# Altium schematic sheet JGL1904_FireAnt_Interface_V1.3.SchDoc(JGL1904_FireAnt_Interface_V1.3)
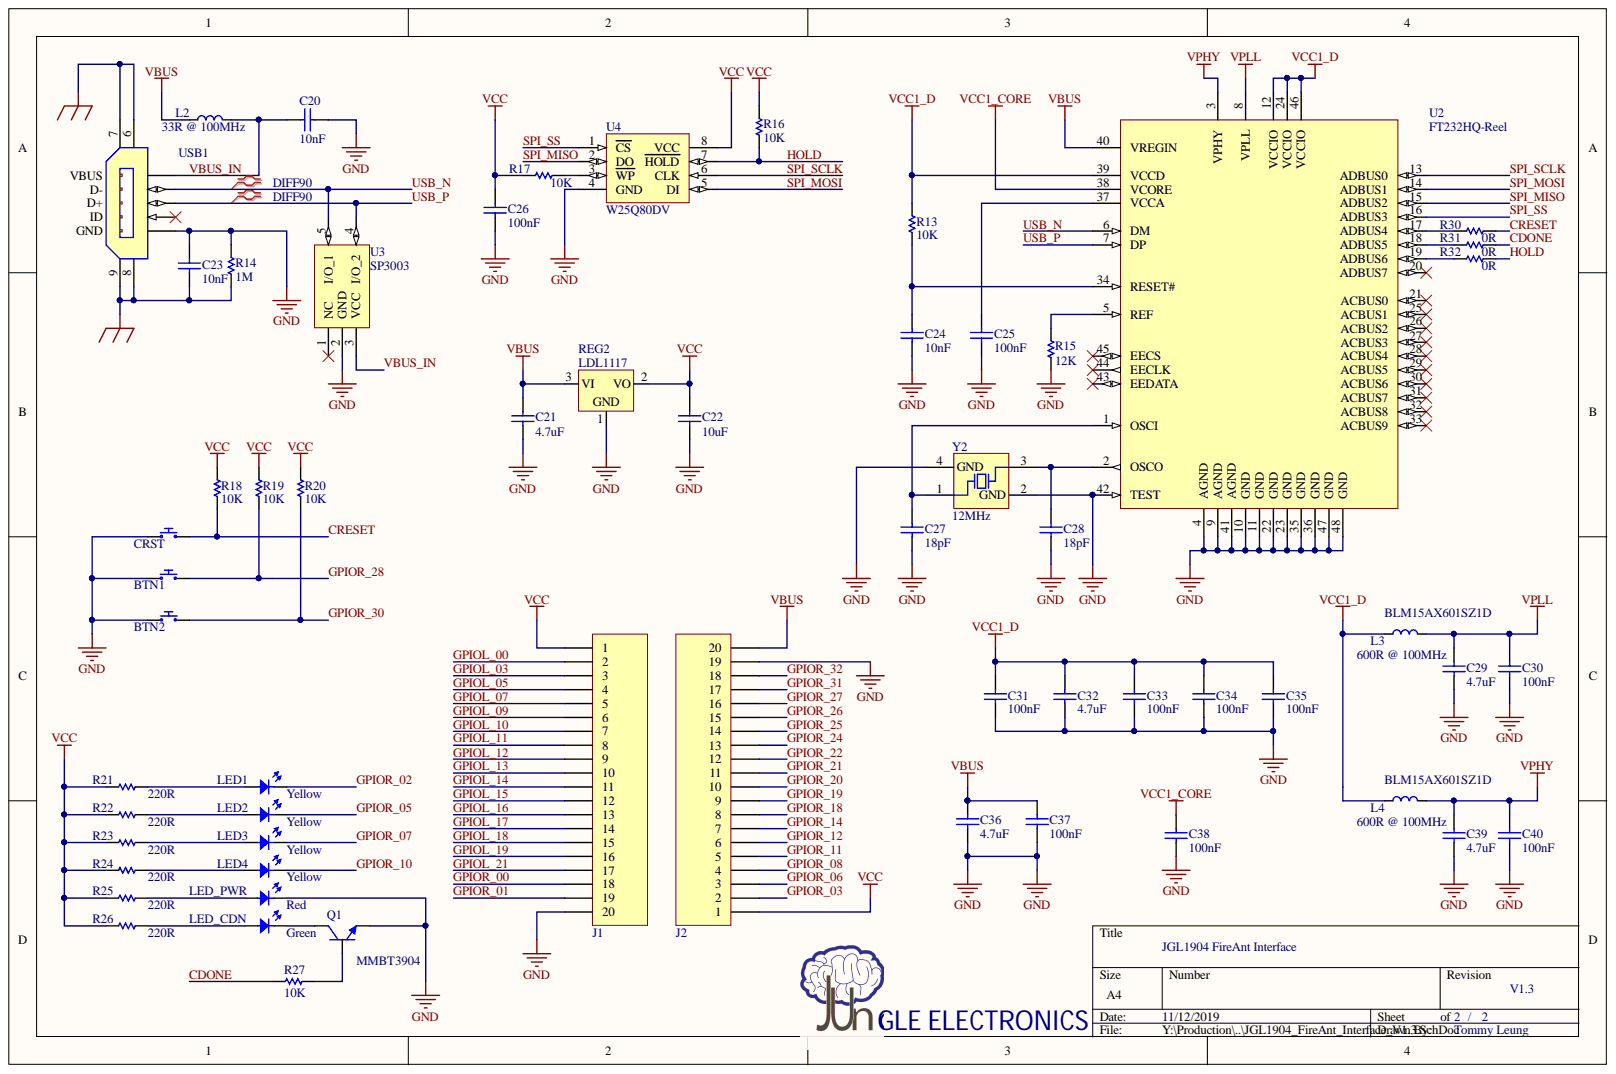
[diagram p0: JGL1904_FireAnt_Interface_V1.3.SchDoc]

## Parts
BTN1  SWITCH_SPST_PUSHON  [PUSHBUTTON-3x4x2]  (value not drawn)
BTN2  SWITCH_SPST_PUSHON  [PUSHBUTTON-3x4x2]  (value not drawn)
C20  value 10nF  [CAPC0402M]  (hidden comment: CAPACITOR)
C21  value 4.7uF  [CAPC0402M]  (hidden comment: CAPACITOR)
C22  value 10uF  [CAPC0402M]  (hidden comment: CAPACITOR)
C23  value 10nF  [CAPC0402M]  (hidden comment: CAPACITOR)
C24  value 10nF  [CAPC0402M]  (hidden comment: CAPACITOR)
C25  value 100nF  [CAPC0402M]  (hidden comment: CAPACITOR)
C26  value 100nF  [CAPC0402M]  (hidden comment: CAPACITOR)
C27  value 18pF  [CAPC0402M]  (hidden comment: CAPACITOR)
C28  value 18pF  [CAPC0402M]  (hidden comment: CAPACITOR)
C29  value 4.7uF  [CAPC0402M]  (hidden comment: CAPACITOR)
C30  value 100nF  [CAPC0402M]  (hidden comment: CAPACITOR)
C31  value 100nF  [CAPC0402M]  (hidden comment: CAPACITOR)
C32  value 4.7uF  [CAPC0402M]  (hidden comment: CAPACITOR)
C33  value 100nF  [CAPC0402M]  (hidden comment: CAPACITOR)
C34  value 100nF  [CAPC0402M]  (hidden comment: CAPACITOR)
C35  value 100nF  [CAPC0402M]  (hidden comment: CAPACITOR)
C36  value 4.7uF  [CAPC0402M]  (hidden comment: CAPACITOR)
C37  value 100nF  [CAPC0402M]  (hidden comment: CAPACITOR)
C38  value 100nF  [CAPC0402M]  (hidden comment: CAPACITOR)
C39  value 4.7uF  [CAPC0402M]  (hidden comment: CAPACITOR)
C40  value 100nF  [CAPC0402M]  (hidden comment: CAPACITOR)
CRST  SWITCH_SPST_PUSHON  [PUSHBUTTON-3x4x2]  (value not drawn)
J1  Header  [PH-1R20P-2M54]  (value not drawn)
J2  Header  [PH-1R20P-2M54]  (value not drawn)
L2  value 33R @ 100MHz  [RESC0603M]  (hidden comment: FERRITE_BEADS)
L3  value 600R @ 100MHz  Mfr. PN: BLM15AX601SZ1D  [RESC0402M]  (hidden comment: FERRITE_BEADS)
L4  value 600R @ 100MHz  Mfr. PN: BLM15AX601SZ1D  [RESC0402M]  (hidden comment: FERRITE_BEADS)
LED1  LED  value Yellow  [LED0603]
LED2  LED  value Yellow  [LED0603]
LED3  LED  value Yellow  [LED0603]
LED4  LED  value Yellow  [LED0603]
LED_CDN  LED  value Green  [LED0603]
LED_PWR  LED  value Red  [LED0603]
Q1  MMBT3904  [SOT-23]
R13  value 10K  [RESC0402M]  (hidden comment: RESISTOR)
R14  value 1M  [RESC0402M]  (hidden comment: RESISTOR)
R15  value 12K  [RESC0402M]  (hidden comment: RESISTOR)
R16  value 10K  [RESC0402M]  (hidden comment: RESISTOR)
R17  value 10K  [RESC0402M]  (hidden comment: RESISTOR)
R18  value 10K  [RESC0402M]  (hidden comment: RESISTOR)
R19  value 10K  [RESC0402M]  (hidden comment: RESISTOR)
R20  value 10K  [RESC0402M]  (hidden comment: RESISTOR)
R21  value 220R  [RESC0402M]  (hidden comment: RESISTOR)
R22  value 220R  [RESC0402M]  (hidden comment: RESISTOR)
R23  value 220R  [RESC0402M]  (hidden comment: RESISTOR)
R24  value 220R  [RESC0402M]  (hidden comment: RESISTOR)
R25  value 220R  [RESC0402M]  (hidden comment: RESISTOR)
R26  value 220R  [RESC0402M]  (hidden comment: RESISTOR)
R27  value 10K  [RESC0402M]  (hidden comment: RESISTOR)
R30  value 0R  [RESC0402M]  (hidden comment: RESISTOR)
R31  value 0R  [RESC0402M]  (hidden comment: RESISTOR)
R32  value 0R  [RESC0402M]  (hidden comment: RESISTOR)
REG2  LDL1117  [SOT-223]
U2  FT232HQ-Reel  [QFN48_N]
U3  SP3003  [SOT-553]
U4  W25Q80DV  [SOIC-8]
USB1  105017-0001  [105017-0001]  (value not drawn)
Y2  value 12MHz  [XTAL-3225]  (hidden comment: XTAL_S3225A)

## Nets
GND: BTN1.1 BTN2.1 C20.2 C21.2 C22.2 C23.1 C24.1 C25.2 C26.1 C27.2 C28.2 C29.1 C30.1 C31.2 C32.1 C33.2 C34.2 C35.2 C36.2 C37.2 C38.2 C39.1 C40.1 CRST.1 J1.20 J2.19 LED_PWR.2 Q1.2 R14.2 R15.1 REG2.1 U2.4(AGND) U2.9(AGND) U2.10(GND) U2.11(GND) U2.22(GND) U2.23(GND) U2.35(GND) U2.36(GND) U2.41(AGND) U2.42(TEST) U2.47(GND) U2.48(GND) U3.2(GND) U4.4(GND) USB1.5 Y2.2(GND) Y2.4(GND)
Shield_USB: C23.2 R14.1 USB1.6 USB1.7 USB1.8 USB1.9
VBUS: C21.1 C36.1 C37.1 J2.20 L2.1 REG2.3 U2.40(VREGIN)
VCC: C22.1 C26.2 J1.1 J2.1 R16.1 R17.1 R18.1 R19.1 R20.1 R21.2 R22.2 R23.2 R24.2 R25.2 R26.2 REG2.2 U4.8(VCC)
VCC1_CORE: C38.1 U2.38(VCORE)
VCC1_D: C31.1 C32.2 C33.1 C34.1 C35.1 L3.1 L4.1 R13.2 U2.12(VCCIO) U2.24(VCCIO) U2.39(VCCD) U2.46(VCCIO)
VPHY: C39.2 C40.2 L4.2 U2.3(VPHY)
VPLL: C29.2 C30.2 L3.2 U2.8(VPLL)
CDONE: R27.2 R31.1
CRESET: CRST.2 R18.2 R30.1
GPIOL_00: J1.2
GPIOL_03: J1.3
GPIOL_05: J1.4
GPIOL_07: J1.5
GPIOL_09: J1.6
GPIOL_10: J1.7
GPIOL_11: J1.8
GPIOL_12: J1.9
GPIOL_13: J1.10
GPIOL_14: J1.11
GPIOL_15: J1.12
GPIOL_16: J1.13
GPIOL_17: J1.14
GPIOL_18: J1.15
GPIOL_19: J1.16
GPIOL_21: J1.17
GPIOR_00: J1.18
GPIOR_01: J1.19
GPIOR_02: LED1.2
GPIOR_03: J2.2
GPIOR_05: LED2.2
GPIOR_06: J2.3
GPIOR_07: LED3.2
GPIOR_08: J2.4
GPIOR_10: LED4.2
GPIOR_11: J2.5
GPIOR_12: J2.6
GPIOR_14: J2.7
GPIOR_18: J2.8
GPIOR_19: J2.9
GPIOR_20: J2.10
GPIOR_21: J2.11
GPIOR_22: J2.12
GPIOR_24: J2.13
GPIOR_25: J2.14
GPIOR_26: J2.15
GPIOR_27: J2.16
GPIOR_28: BTN1.2 R19.2
GPIOR_30: BTN2.2 R20.2
GPIOR_31: J2.17
GPIOR_32: J2.18
HOLD: R16.2 R32.1 U4.7(HOLD)
SPI_MISO: U2.15(ADBUS2) U4.2(DO)
SPI_MOSI: U2.14(ADBUS1) U4.5(DI)
SPI_SCLK: U2.13(ADBUS0) U4.6(CLK)
SPI_SS: U2.16(ADBUS3) U4.1(CS)
USB_N: U2.6(DM) U3.5(I/O_1) USB1.2
USB_P: U2.7(DP) U3.4(I/O_2) USB1.3
VBUS_IN: C20.1 L2.2 U3.3(VCC) USB1.1
NetC24_2: C24.2 R13.1 U2.34(RESET#)
NetC25_1: C25.1 U2.37(VCCA)
NetC27_1: C27.1 U2.1(OSCI) Y2.1
NetC28_1: C28.1 U2.2(OSCO) Y2.3
NetLED1_1: LED1.1 R21.1
NetLED2_1: LED2.1 R22.1
NetLED3_1: LED3.1 R23.1
NetLED4_1: LED4.1 R24.1
NetLED_CDN_1: LED_CDN.1 R26.1
NetLED_CDN_2: LED_CDN.2 Q1.3
NetLED_PWR_1: LED_PWR.1 R25.1
NetQ1_1: Q1.1 R27.1
NetR15_2: R15.2 U2.5(REF)
NetR17_2: R17.2 U4.3(WP)
NetR30_2: R30.2 U2.17(ADBUS4)
NetR31_2: R31.2 U2.18(ADBUS5)
NetR32_2: R32.2 U2.19(ADBUS6)

Not connected: U2 20(ADBUS7) 21(ACBUS0) 25(ACBUS1) 26(ACBUS2) 27(ACBUS3) 28(ACBUS4) 29(ACBUS5) 30(ACBUS6) 31(ACBUS7) 32(ACBUS8) 33(ACBUS9) 43(EEDATA) 44(EECLK) 45(EECS); U3 1(NC); USB1 4

## Library data of the parts
BTN1 BTN2 CRST = SWITCH_SPST_PUSHON: Single Pole Single Throw Push-ON Switch; Mfr. PN: 3x4 小龜 二腳 包腳 無定位; Supplier 1: LAXXSCOM; Component Kind: Standard; Library Name: Jungle_ADLibrary.IntLib
C20 C23 C24 = CAPACITOR: Mfr. PN: GCM155R71H103KA5J; Supplier Part Number 1: 81-GCM155R71H103KA5J; Supplier 1: Mouser; Description: Capacitor; Component Kind: Standard; Library Name: Jungle_ADLibrary.IntLib
C21 C29 C32 C36 C39 = CAPACITOR: Mfr. PN: GRM155R60J475ME7J; Supplier Part Number 1: 81-GRM155R60J475ME7J; Supplier 1: Mouser; Description: Capacitor; Component Kind: Standard; Library Name: Jungle_ADLibrary.IntLib
C22 = CAPACITOR: Mfr. PN: GRM155C80J106ME1J; Supplier Part Number 1: 81-GRM155C80J106ME1J; Supplier 1: Mouser; Description: Capacitor; Component Kind: Standard; Library Name: Jungle_ADLibrary.IntLib
C25 C26 C30 C31 C33-C35 C37 C38 C40 = CAPACITOR: Mfr. PN: EMK105B7104KV-F; Supplier Part Number 1: 963-EMK105B7104KV-F; Supplier 1: Mouser; Description: Capacitor; Component Kind: Standard; Library Name: Jungle_ADLibrary.IntLib
C27 C28 = CAPACITOR: Mfr. PN: GCM1555C1H180JA6D; Supplier Part Number 1: 81-GCM1555C1H180JA6D; Supplier 1: Mouser; Description: Capacitor; Component Kind: Standard; Library Name: Jungle_ADLibrary.IntLib
J1 J2 = PH_1R20P: Pin Header 1 Row 20 Pin; Component Kind: Standard; Library Name: Jungle_ADLibrary.IntLib
L2 = FERRITE_BEADS: Ferrite Beads; Mfr. PN: BK1608HS330-T; Supplier Part Number 1: 963-BK1608HS330-T; Supplier 1: Mouser; Component Kind: Standard; Library Name: Jungle_ADLibrary.IntLib
L3 L4 = FERRITE_BEADS: Ferrite Beads; Value: 600R @ 100MHz; Supplier Part Number 1: 81-BLM15AX601SZ1D; Supplier 1: Mouser; Component Kind: Standard; Library Name: Jungle_ADLibrary.IntLib
LED1-LED4 = LED: Light Emitter Diode; Mfr. PN: LTST-C191KSKT; Supplier Part Number 1: 859-LTST-C191KSKT; Supplier 1: Mouser; Component Kind: Standard; Library Name: Jungle_ADLibrary.IntLib
LED_CDN = LED: Light Emitter Diode; Mfr. PN: LTST-C191KGKT; Supplier Part Number 1: 859-LTST-C191KGKT; Supplier 1: Mouser; Component Kind: Standard; Library Name: Jungle_ADLibrary.IntLib
LED_PWR = LED: Light Emitter Diode; Mfr. PN: LTST-C191KRKT; Supplier Part Number 1: 859-LTST-C191KRKT; Supplier 1: Mouser; Component Kind: Standard; Library Name: Jungle_ADLibrary.IntLib
Q1 = MMBT3904: NPN General Purpose Amplifier; Mfr. PN: MMBT3904,215; Value: MMBT3904; Supplier Part Number 1: 771-MMBT3904T/R; Supplier 1: Mouser; Component Kind: Standard; Library Name: Jungle_ADLibrary.IntLib
R13 R16-R20 R27 = RESISTOR: Mfr. PN: RC0402FR-0710KL; Supplier Part Number 1: 603-RC0402FR-0710KL; Supplier 1: Mouser; Description: Resistor; Component Kind: Standard; Library Name: Jungle_ADLibrary.IntLib
R14 = RESISTOR: Mfr. PN: RC0402FR-071ML; Supplier Part Number 1: 603-RC0402FR-071ML; Supplier 1: Mouser; Description: Resistor; Component Kind: Standard; Library Name: Jungle_ADLibrary.IntLib
R15 = RESISTOR: Mfr. PN: RC0402FR-0712KL; Supplier Part Number 1: 603-RC0402FR-0712KL; Supplier 1: Mouser; Description: Resistor; Component Kind: Standard; Library Name: Jungle_ADLibrary.IntLib
R21-R26 = RESISTOR: Mfr. PN: RC0402FR-07220RL; Supplier Part Number 1: 603-RC0402FR-07220RL; Supplier 1: Mouser; Description: Resistor; Component Kind: Standard; Library Name: Jungle_ADLibrary.IntLib
R30-R32 = RESISTOR: Mfr. PN: RC0402FR-070RL; Supplier Part Number 1: 603-RC0402FR-070RL; Supplier 1: Mouser; Description: Resistor; Component Kind: Standard; Library Name: Jungle_ADLibrary.IntLib
REG2 = LDL1117: 1.2A High PSRR Low-Dropout Linear Voltage Regulator; Mfr. PN: LDL1117S33R; Supplier Part Number 1: 511-LDL1117S33R; Supplier 1: Mouser; Component Kind: Standard; Library Name: Jungle_ADLibrary.IntLib
U2 = FT232HQ-Reel: Single Channel Hi-Speed USB to Multipurpose UART/FIFO IC, 48-pin QFN, Tape and Reel; Manufacturer: FTDI; Supplier Part Number 2: 895-FT232HQ-TRAY; Supplier Part Number 1: 895-FT232HQ-REEL; Supplier 2: Mouser; Supplier 1: Mouser; PartNumber: FT232HQ-Reel; PackageVersion: Dec-12; PackageReference: QFN48; PackageDescription: 48-Pin Quad Flat No-Lead 0.5 mm Pitch, 6.2 x 6.2 mm Exposed Pad; Mfr. PN: FT232HQ; Library Name: FTDI FT Series.IntLib; DatasheetVersion: ver 1.8, Dec-2012; ComponentLink2URL: http://www.ftdichip.com/Support/Documents/DataSheets/ICs/DS_FT232H.pdf; ComponentLink2Description: Datasheet; ComponentLink1URL: http://www.ftdichip.com/; ComponentLink1Description: Manufacturer URL; Component Kind: Standard
U3 = SP3003: TVS Diode Arrays, HDMI, DVI, USB2.0, IEEE 1394 Application; Mfr. PN: SP3003-02XTG; Value: SP3003; Supplier Part Number 1: 576-SP3003-02XTG; Supplier 1: Mouser; Component Kind: Standard; Library Name: Jungle_ADLibrary.IntLib
U4 = W25Q80DV: 3V 8M-bit Serial Flash Memory; Mfr. PN: W25Q80DVSNIG; Supplier Part Number 1: 454-W25Q80DVSNIG; Supplier 1: Mouser; Component Kind: Standard; Library Name: Jungle_ADLibrary.IntLib
USB1 = USB_CON_MICRO: Micro-USB B Receptacle, Bottom Mount, Surface Mount; Supplier Part Number 1: 538-105017-0001; Supplier 1: Mouser; Mfr. PN: 105017-0001; Component Kind: Standard; Library Name: Jungle_ADLibrary.IntLib
Y2 = XTAL_S3225A: Quantz Crystal, S3225A, 12M, -+20ppm, -+30ppm(-40~+85), 18pF, 100ohms; Mfr. PN: S3225A/12M/-+20ppm/-+30ppm(-40~+85)/18pF/100ohms; Component Kind: Standard; Library Name: Jungle_ADLibrary.IntLib
